# Altium schematic sheet switches_connectors.SchDoc(switches_connectors)
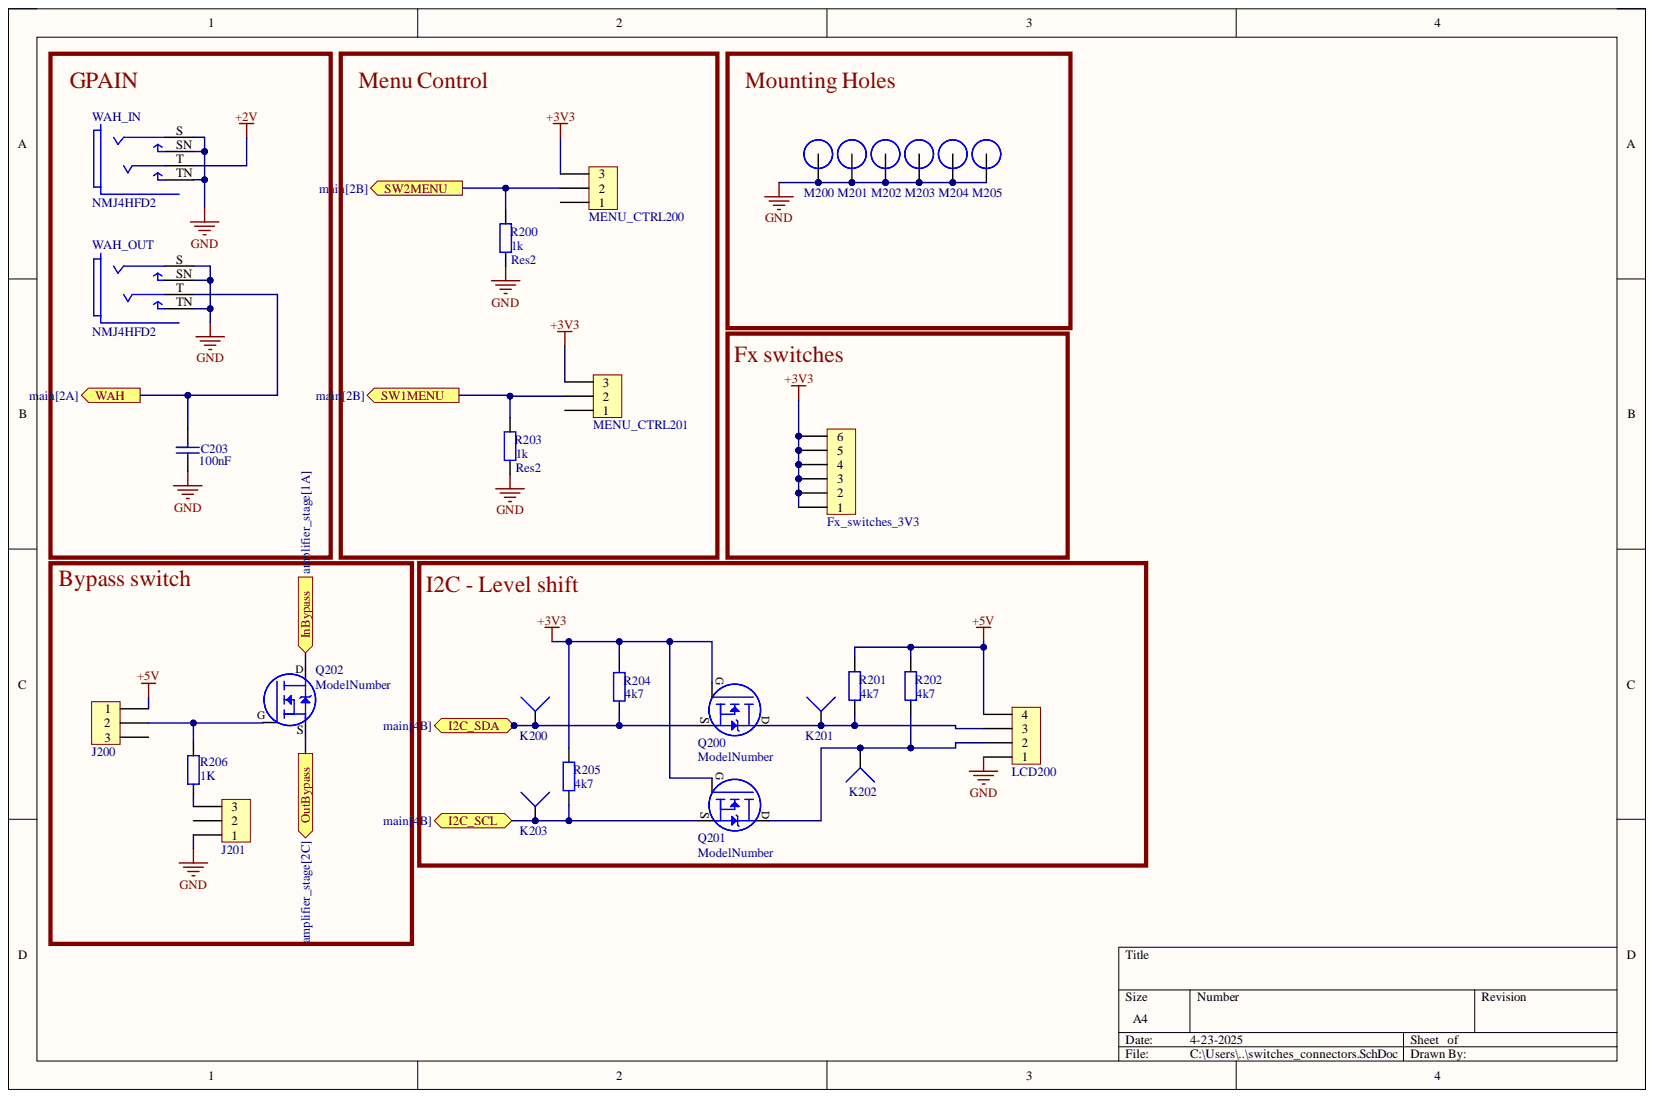
[diagram p0: switches_connectors.SchDoc]

## Parts
C203  value 100nF  [Cap-1]  (hidden comment: Cap)
Fx_switches_3V3  Header 1x6  [Header 1x6]  (value not drawn)
J200  Header 1x3  [Header 1x3]  (value not drawn)
J201  Header 1x3  [Header 1x3]  (value not drawn)
K200  Wire  [Wire 1.5mm]  (value not drawn)
K201  Wire  [Wire 1.5mm]  (value not drawn)
K202  Wire  [Wire 1.5mm]  (value not drawn)
K203  Wire  [Wire 1.5mm]  (value not drawn)
LCD200  Header 1x4  [Header 1x4]  (value not drawn)
M200  Screw Hole  [M3 Hole]  (value not drawn)  (no BOM)
M201  Screw Hole  [M3 Hole]  (value not drawn)  (no BOM)
M202  Screw Hole  [M3 Hole]  (value not drawn)  (no BOM)
M203  Screw Hole  [M3 Hole]  (value not drawn)  (no BOM)
M204  Screw Hole  [M3 Hole]  (value not drawn)  (no BOM)
M205  Screw Hole  [M3 Hole]  (value not drawn)  (no BOM)
MENU_CTRL200  Header 1x3  [Header 1x3]  (value not drawn)
MENU_CTRL201  Header 1x3  [Header 1x3]  (value not drawn)
Q200  ModelNum  Model: ModelNumber  [TO-92_straight]
Q201  ModelNum  Model: ModelNumber  [TO-92_straight]
Q202  ModelNum  Model: ModelNumber  [TO-92_straight]
R200  Res2  value 1k  [AXIAL-0.4 PCBK]
R201  value 4k7  [AXIAL-0.4 PCBK]
R202  value 4k7  [AXIAL-0.4 PCBK]
R203  Res2  value 1k  [AXIAL-0.4 PCBK]
R204  value 4k7  [AXIAL-0.4 PCBK]
R205  value 4k7  [AXIAL-0.4 PCBK]
R206  value 1K  [RES THT 9mm]  (hidden comment: Resistor)
WAH_IN  NMJ4HFD2  [FP-NMJ4HFD2-MFG]
WAH_OUT  NMJ4HFD2  [FP-NMJ4HFD2-MFG]

## Ports
I2C_SCL, I2C_SDA, InBypass, OutBypass, SW1MENU, SW2MENU, WAH

## Nets
+2V: WAH_IN.T
+3V3: Fx_switches_3V3.1 Fx_switches_3V3.2 Fx_switches_3V3.3 Fx_switches_3V3.4 Fx_switches_3V3.5 Fx_switches_3V3.6 MENU_CTRL200.3 MENU_CTRL201.3 Q200.1 Q201.1 R204.2 R205.2
+5V: J200.1 LCD200.4 R201.2 R202.2
GND: C203.1 J201.1 LCD200.1 M200.1 M201.1 M202.1 M203.1 M204.1 M205.1 R200.1 R203.1 WAH_IN.S WAH_IN.SN WAH_IN.TN WAH_OUT.S WAH_OUT.SN WAH_OUT.TN
I2C_SCL: K203.1 Q201.3 R205.1 ; port I2C_SCL
I2C_SDA: K200.1 Q200.3 R204.1 ; port I2C_SDA
InBypass: Q202.2 ; port InBypass
OutBypass: Q202.3 ; port OutBypass
SW1MENU: MENU_CTRL201.2 R203.2 ; port SW1MENU
SW2MENU: MENU_CTRL200.2 R200.2 ; port SW2MENU
WAH: C203.2 WAH_OUT.T ; port WAH
NetJ200_2: J200.2 Q202.1 R206.2
NetJ201_3: J201.3 R206.1
NetK201_1: K201.1 LCD200.3 Q200.2 R201.1
NetK202_1: K202.1 LCD200.2 Q201.2 R202.1

Not connected: J200 3; J201 2; MENU_CTRL200 1; MENU_CTRL201 1

## Library data of the parts
C203 = Capacitor 1 space: Component Kind: Standard; Library Name: AAURacing.IntLib
Q200-Q202 = MOSFET N-Channel: Component Kind: Standard; Library Name: AAURacing.IntLib
R200-R205 = Res PCBK: Resistor; Component Kind: Standard; LatestRevisionDate: 17-Jul-2002; LatestRevisionNote: Re-released for DXP Platform.; PackageReference: AXIAL-0.4; Published: 8-Jun-2000; Library Name: pcb_kursus_lib2020 (1).IntLib; Publisher: Altium Limited
WAH_IN WAH_OUT = CMP-78736-000001-1: Conn 1/4" Mono Jack F 3 POS Solder RA Thru-Hole 3 Terminal 1 Port; Manufacturer: Neutrik; Conn Orientation: Horizontal; Current Rating: 0.5A; Is Female: yes; Number Of Contacts: 2; Voltage Rating: 50V; Automotive: No; Category: Conn; Component Kind: Standard; ComponentLink2Description: Datasheet; ComponentLink2URL: https://www.neutrik.com/en/product/nmj4hfd2.pdf; Contact Material: Silver; Device Class L1: Connectors; Device Class L2: Audio / Video Connectors; Device Class L3: unset; Footprint Url: https://www.neutrik.com/media/8576/download/st-nmj4hfd2.pdf?v=1; Height: 15.89mm; Lead Free: Yes; Library Name: Altium Content Vault; Manufacturer Part Number: NMJ4HFD2; Mouser Description: Phone Audio Connector, Socket, 2, PCB Mount, Metal Body, Silver Plated Contacts; Octopart: https://octopart.com/nmj4hfd2-neutrik-758952; Package: 0; RoHS: TRUE; Temperature: -25°C to +70°C; Temperature Range High: +70°C; Temperature Range Low: -25°C
Fx_switches_3V3 = Header 1x6: Component Kind: Standard; Library Name: AAURacing.IntLib
J200 J201 MENU_CTRL200 MENU_CTRL201 = Header 1x3: Component Kind: Standard; Library Name: AAURacing.IntLib
K200-K203 = Wire: Component Kind: Standard; Library Name: AAURacing.IntLib
LCD200 = Header 1x4: Component Kind: Standard; Library Name: AAURacing.IntLib
R206 = Resistor: Component Kind: Standard; Library Name: AAURacing.IntLib
M200-M205 = Screw Hole: Library Name: AAURacing.IntLib
